# Altium schematic sheet Sheet6.SchDoc
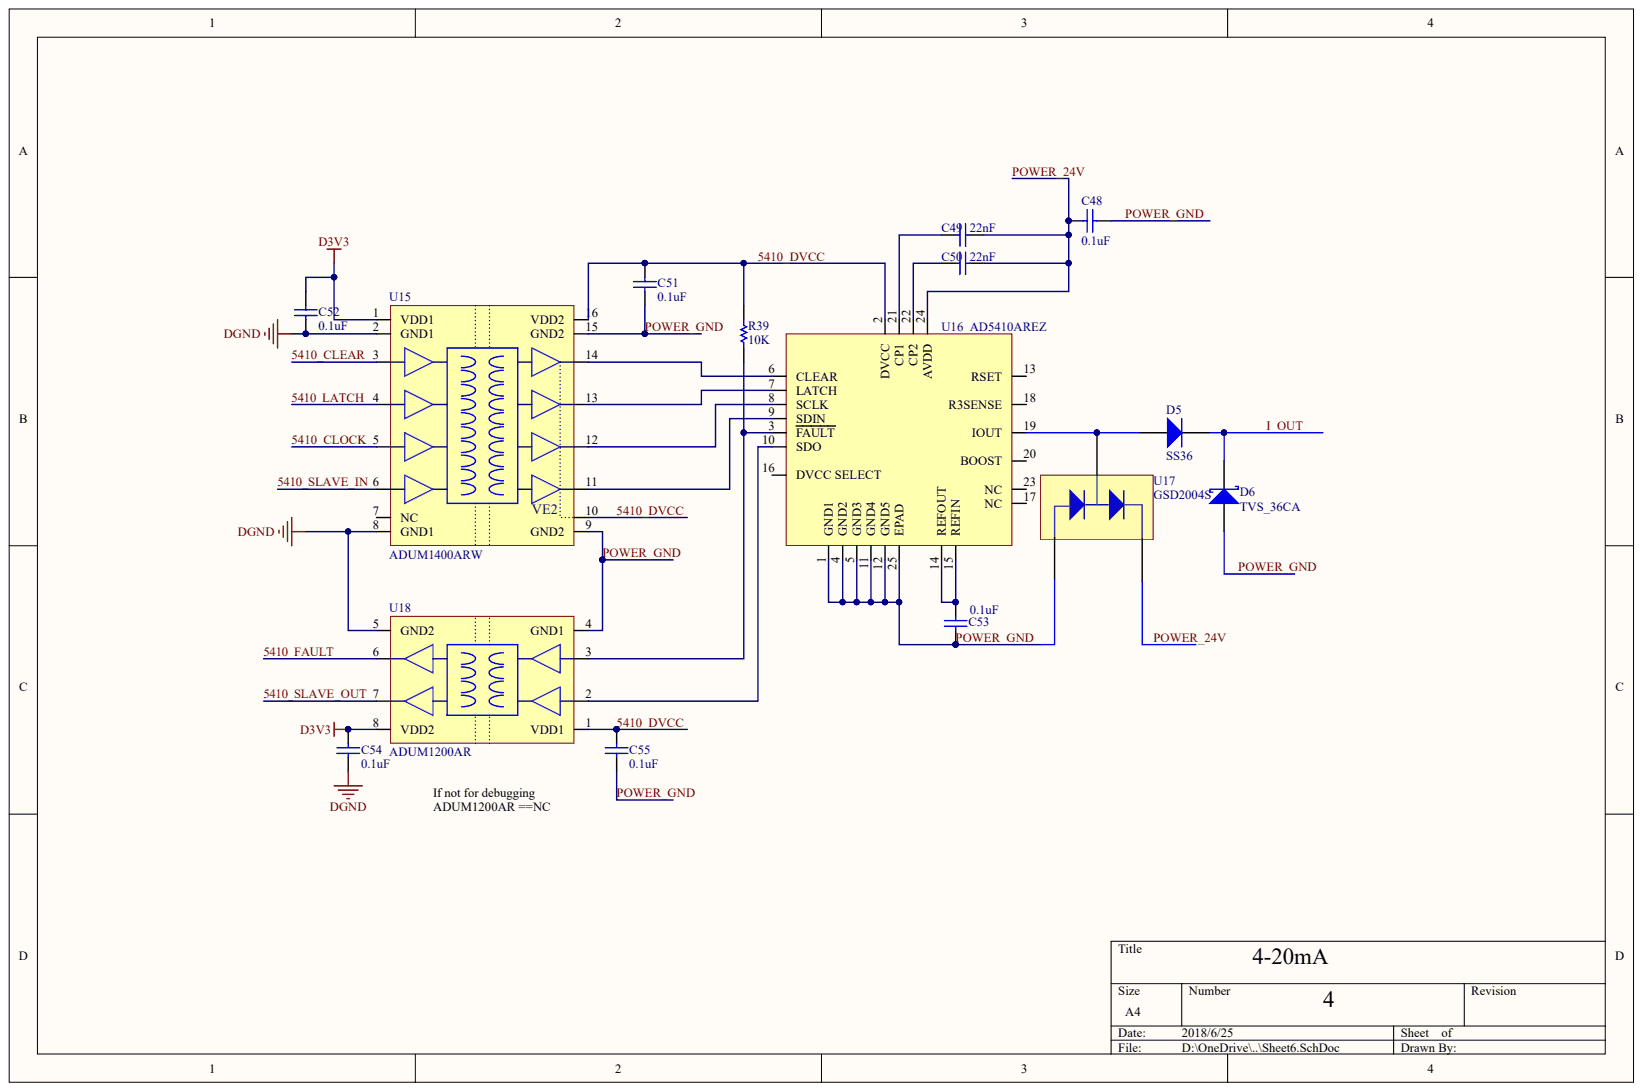
[diagram p0: Sheet6.SchDoc]

## Parts
C48  value 0.1uF  (hidden comment: Cap)
C49  value 22nF  (hidden comment: Cap)
C50  value 22nF  (hidden comment: Cap)
C51  value 0.1uF  (hidden comment: Cap)
C52  value 0.1uF  (hidden comment: Cap)
C53  value 0.1uF  (hidden comment: Cap)
C54  value 0.1uF  (hidden comment: Cap)
C55  value 0.1uF  (hidden comment: Cap)
D5  SS36
D6  TVS_36CA
R39  value 10K  (hidden comment: Res1)
U15  ADUM1400ARW
U16  AD5410AREZ
U17  GSD2004S
U18  ADUM1200AR

## Nets
D3V3: C52.1 C54.1 U15.1(VDD1) U18.8(VDD2)
DGND: C52.2 C54.2 U15.2(GND1) U15.8(GND1) U18.5(GND2)
5410_CLEAR: U15.3
5410_CLOCK: U15.5
5410_DVCC: C51.1 C55.1 R39.2 U15.10 U15.16(VDD2) U16.2(DVCC) U18.1(VDD1)
5410_FAULT: U18.6
5410_LATCH: U15.4
5410_SLAVE_IN: U15.6
5410_SLAVE_OUT: U18.7
I_OUT: D5.2 D6.2
POWER_24V: C48.1 C49.2 C50.2 U16.24(AVDD) U17.2
POWER_GND: C48.2 C51.2 C53.2 C55.2 D6.1 U15.9(GND2) U15.15(GND2) U16.1(GND1) U16.4(GND2) U16.5(GND3) U16.11(GND4) U16.12(GND5) U16.25(EPAD) U17.1 U18.4(GND1)
NetC49_1: C49.1 U16.21(CP1)
NetC50_1: C50.1 U16.22(CP2)
NetC53_1: C53.1 U16.14(REFOUT) U16.15(REFIN)
NetD5_1: D5.1 U16.19(IOUT) U17.3
NetR39_1: R39.1 U16.3(FAULT) U18.3
NetU15_11: U15.11 U16.9(SDIN)
NetU15_12: U15.12 U16.8(SCLK)
NetU15_13: U15.13 U16.7(LATCH)
NetU15_14: U15.14 U16.6(CLEAR)
NetU16_10: U16.10(SDO) U18.2

Not connected: U15 7(NC); U16 13(RSET) 16(DVCC_SELECT) 17(NC) 18(R3SENSE) 20(BOOST) 23(NC)

## Library data of the parts
C48-C55 D5 D6 R39 U15-U18 = (no symbol name)
  C48-C55: Published: 8-Jun-2000; LatestRevisionDate: 17-Jul-2002; LatestRevisionNote: Re-released for DXP Platform.; PackageReference: RAD-0.3
  D5: Published: 8-Jun-2000; LatestRevisionDate: 17-Jul-2002; LatestRevisionNote: Re-released for DXP Platform.; DatasheetVersion: 1997; PackageReference: DO-35; Code_JEDEC: DO-204-AH; ComponentLink1Description: Manufacturer Link; ComponentLink1URL: http://www.fairchildsemi.com/; ComponentLink2Description: Datasheet; ComponentLink2URL: http://www.fairchildsemi.com/ds/1N%2F1N4148.pdf
  D6: Published: 8-Jun-2000; LatestRevisionDate: 17-Jul-2002; LatestRevisionNote: Re-released for DXP Platform.; PackageReference: SMB; Code_JEDEC: DO-214-AA
  R39: Published: 8-Jun-2000; LatestRevisionDate: 17-Jul-2002; LatestRevisionNote: Re-released for DXP Platform.; PackageReference: AXIAL-0.3
  C48-C55 D5 D6 R39: Publisher: Altium Limited
